# Altium schematic sheet DE-211024_1.SchDoc(DE-211024_1)
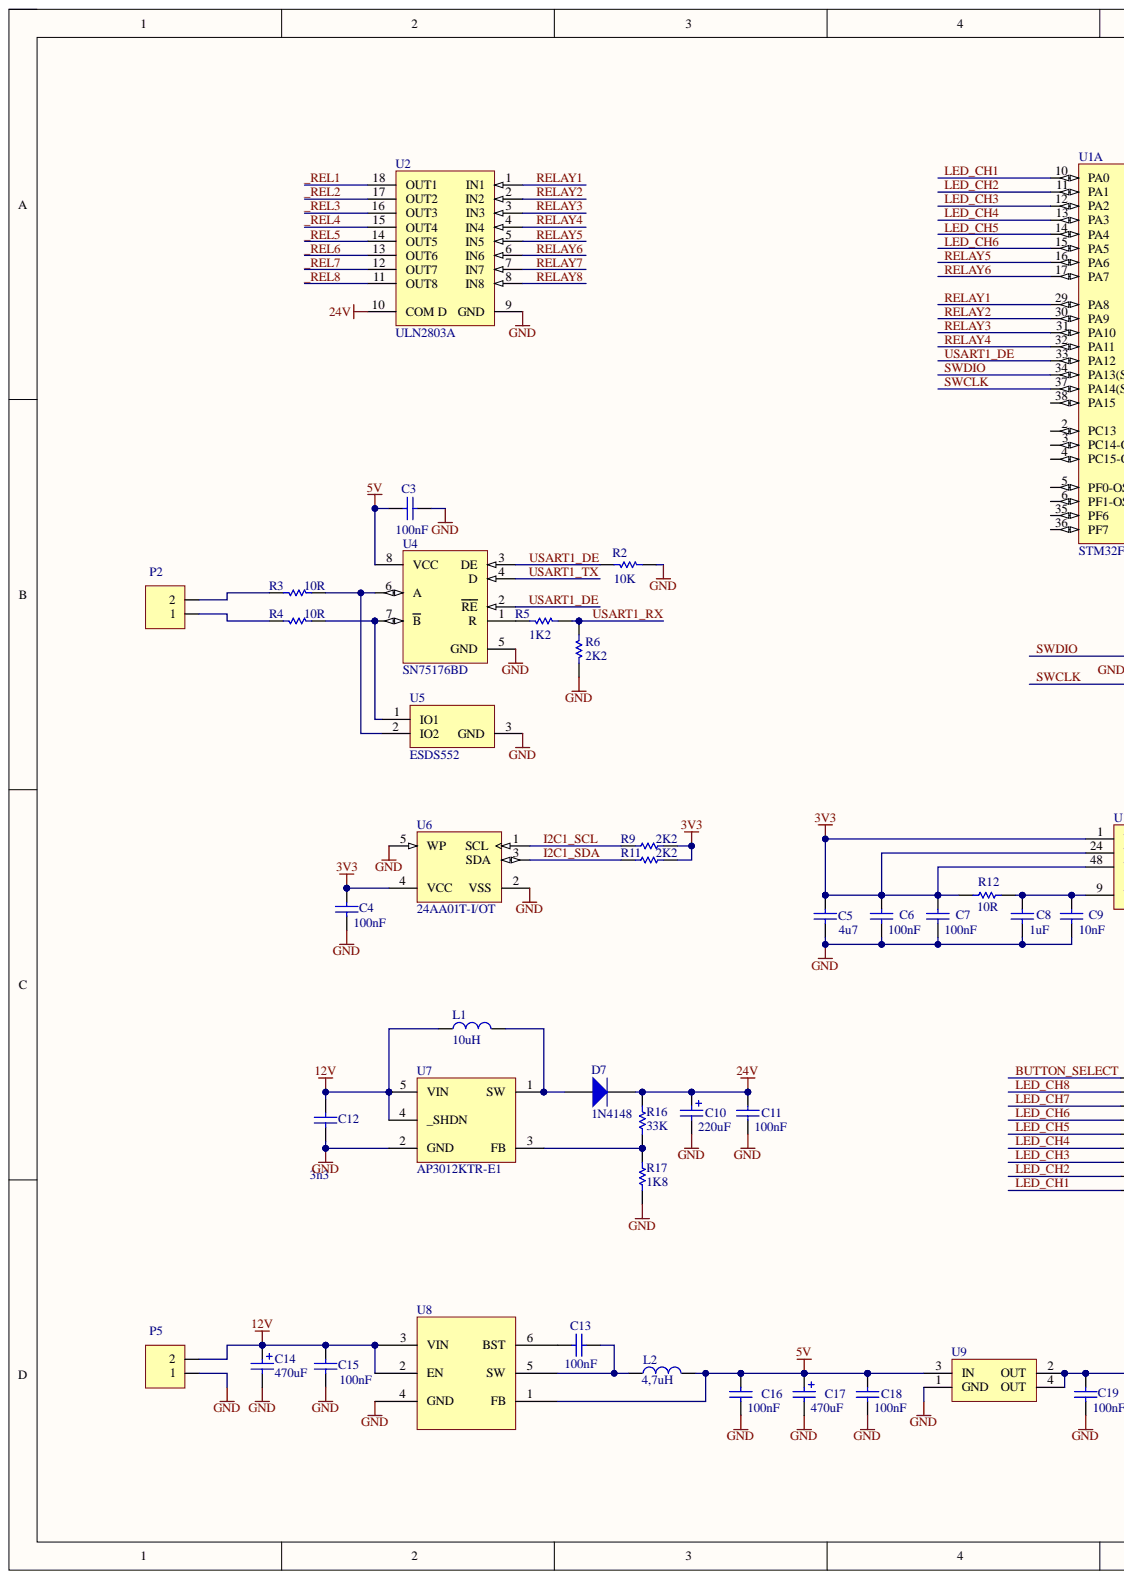
[diagram p0: DE-211024_1.SchDoc, left]
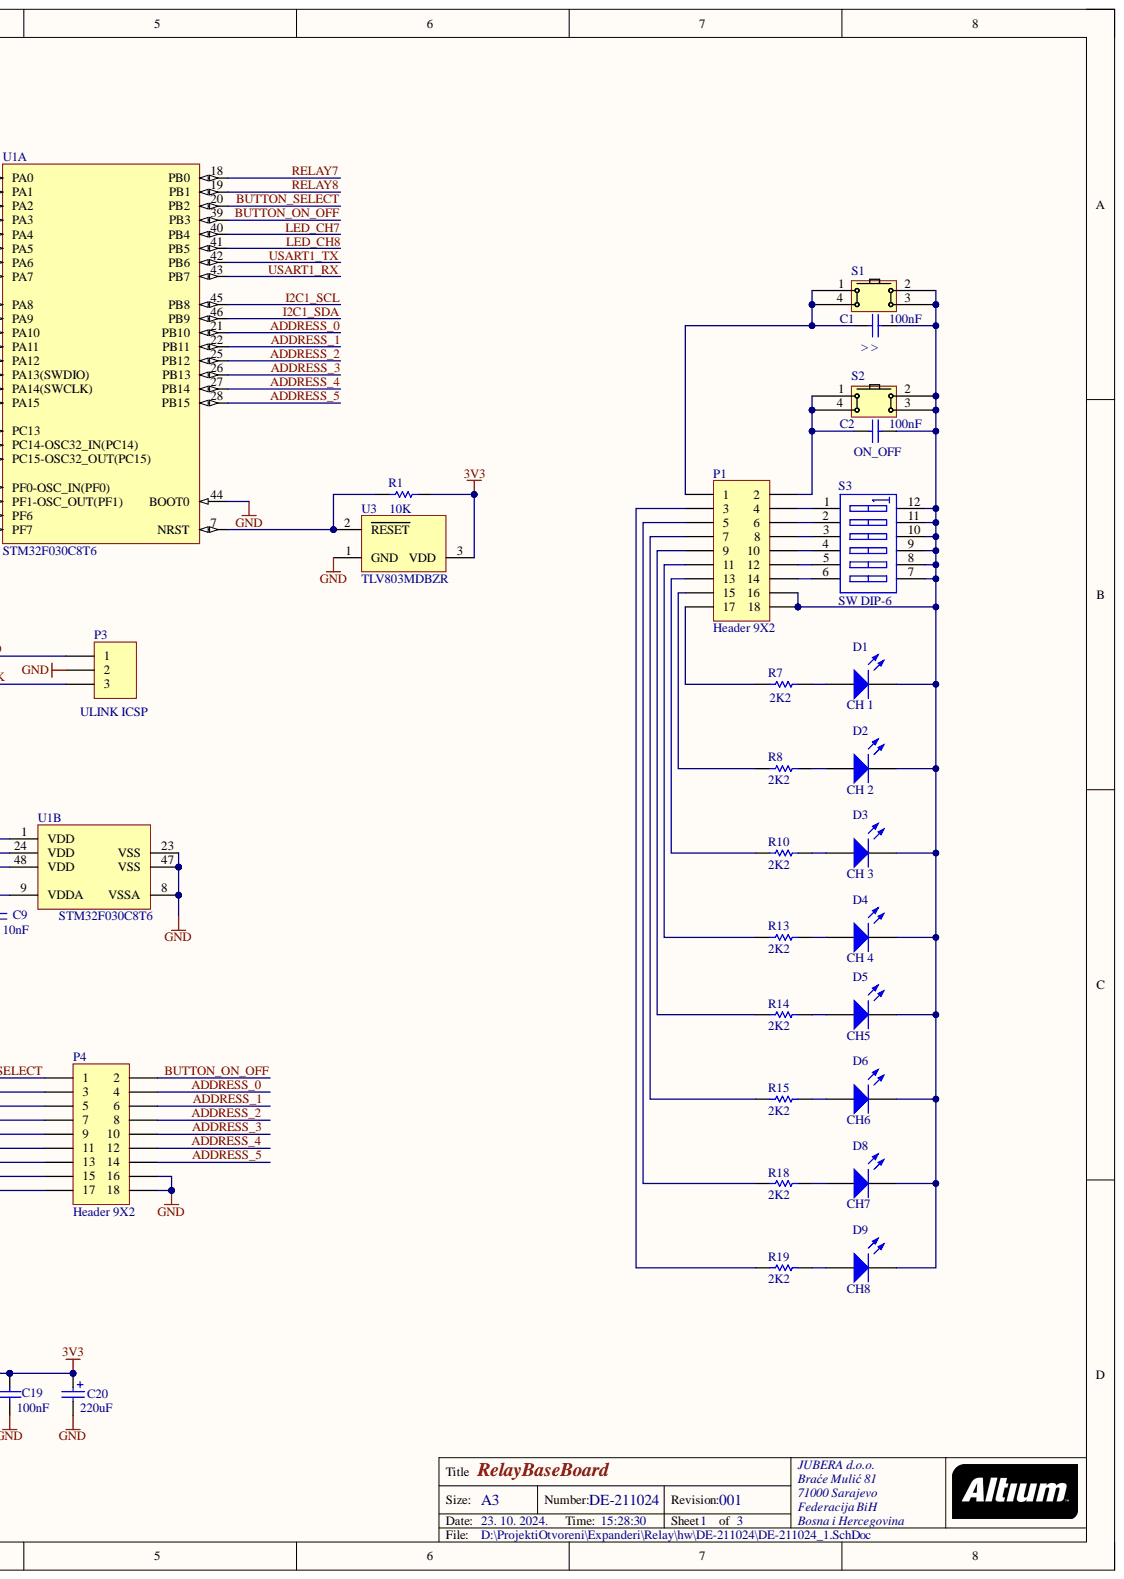
[diagram p1: DE-211024_1.SchDoc, right]

## Parts
C1  value 100nF  [0603]
C2  value 100nF  [0603]
C3  100nF  [0603]
C4  value 100nF  [0603]
C5  4u7  [0805]
C6  100nF  [0603]
C7  100nF  [0603]
C8  1uF  [0603]
C9  10nF  [0603]
C10  220uF  [SMD,D8xL10.2mm]
C11  value 100nF  [0603]
C12  value 3n3  [0603]  (hidden comment: C0603)
C13  value 100nF  [0603]
C14  470uF  [ROQANG VT1V471M1010]
C15  value 100nF  [0603]
C16  value 100nF  [0603]
C17  470uF  [SMD,D6.3xL7.7mm Aluminum Electrolytic Capacitors]
C18  value 100nF  [0603]
C19  value 100nF  [0603]
C20  value 220uF  [SMD,D6.3xL7.7mm Aluminum Electrolytic Capacitors]
D1  CH 1  [1.6X0.8X0.8]
D2  CH 2  [1.6X0.8X0.8]
D3  CH 3  [1.6X0.8X0.8]
D4  CH 4  [1.6X0.8X0.8]
D5  CH5  [1.6X0.8X0.8]
D6  CH6  [1.6X0.8X0.8]
D7  1N4148  [SOD323]
D8  CH7  [1.6X0.8X0.8]
D9  CH8  [1.6X0.8X0.8]
L1  10uH  [YJYCOIN CD32YP0302-100M]
L2  value 4,7uH  [XRNR4030-4.7uH/M]
P1  Header 9X2  [HDR2X9]
P2  (no value)  [TERMINAL BLOK 2]
P3  ULINK ICSP  [HDR1X3]
P4  Header 9X2  [HDR2X9]
P5  (no value)  [TERMINAL BLOK 2]
R1  10K  [ERA-0603]
R2  10K  [ERA-0603]
R3  10R  [ERA-0603]
R4  10R  [ERA-0603]
R5  1K2  [ERA-0603]
R6  2K2  [ERA-0603]
R7  2K2  [ERA-0603]
R8  2K2  [ERA-0603]
R9  2K2  [ERA-0603]
R10  2K2  [ERA-0603]
R11  2K2  [ERA-0603]
R12  10R  [ERA-0603]
R13  2K2  [ERA-0603]
R14  2K2  [ERA-0603]
R15  2K2  [ERA-0603]
R16  value 33K  [ERA-0603]  (hidden comment: 2K2)
R17  value 1K8  [ERA-0603]  (hidden comment: 2K2)
R18  2K2  [ERA-0603]
R19  2K2  [ERA-0603]
S1  > >  [TACTILE_SWITCH]
S2  ON_OFF  [TACTILE_SWITCH]
S3  SW DIP-6  [DIP-12/SW]
U1  STM32F030C8T6  [STM-LQFP48_N]  (parts A,B)
U2  ULN2803A  [SOIC-18_L11.6-W7.5-P1.27-LS10.3-BL]
U3  TLV803MDBZR  [DBZ3_N]
U4  SN75176BD  [D008_N]
U5  ESDS552  [DCK3_N]
U6  24AA01T-I/OT  [SOT-23-OT5_N]
U7  AP3012KTR-E1  [SOT-23-5]
U8  (no value)  [TSOT-26]
U9  (no value)  [ZZ311]

## Nets
3V3: C4.2 C5.1 C6.1 C7.1 C19.2 C20.1 R1.2 R9.2 R11.2 R12.2 U1.1(VDD) U1.24(VDD) U1.48(VDD) U3.3 U6.4(VCC) U9.2(OUT) U9.4(OUT)
5V: C3.1 C16.2 C17.1 C18.2 L2.1 U4.8(VCC) U8.1(FB) U9.3(IN)
12V: C12.2 C14.1 C15.2 L1.2 P5.2 U7.4(_SHDN) U7.5(VIN) U8.2(EN) U8.3(VIN)
24V: C10.1 C11.2 D7.1 R16.2 U2.10(COM_D)
GND: C3.2 C4.1 C5.2 C6.2 C7.2 C8.2 C9.2 C10.2 C11.1 C12.1 C14.2 C15.1 C16.1 C17.2 C18.1 C19.1 C20.2 P3.2 P4.16 P4.18 P5.1 R2.1 R6.2 R17.1 U1.8(VSSA) U1.23(VSS) U1.44(BOOT0) U1.47(VSS) U2.9(GND) U3.1 U4.5(GND) U5.3 U6.2(VSS) U6.5(WP) U7.2(GND) U8.4(GND) U9.1(GND)
_REL1: U2.18(OUT1)
_REL2: U2.17(OUT2)
_REL3: U2.16(OUT3)
_REL4: U2.15(OUT4)
_REL5: U2.14(OUT5)
_REL6: U2.13(OUT6)
_REL7: U2.12(OUT7)
_REL8: U2.11(OUT8)
ADDRESS_0: P4.4 U1.21(PB10)
ADDRESS_1: P4.6 U1.22(PB11)
ADDRESS_2: P4.8 U1.25(PB12)
ADDRESS_3: P4.10 U1.26(PB13)
ADDRESS_4: P4.12 U1.27(PB14)
ADDRESS_5: P4.14 U1.28(PB15)
BUTTON_ON_OFF: P4.2 U1.39(PB3)
BUTTON_SELECT: P4.1 U1.20(PB2)
I2C1_SCL: R9.1 U1.45(PB8) U6.1(SCL)
I2C1_SDA: R11.1 U1.46(PB9) U6.3(SDA)
LED_CH1: P4.17 U1.10(PA0)
LED_CH2: P4.15 U1.11(PA1)
LED_CH3: P4.13 U1.12(PA2)
LED_CH4: P4.11 U1.13(PA3)
LED_CH5: P4.9 U1.14(PA4)
LED_CH6: P4.7 U1.15(PA5)
LED_CH7: P4.5 U1.40(PB4)
LED_CH8: P4.3 U1.41(PB5)
RELAY1: U1.29(PA8) U2.1(IN1)
RELAY2: U1.30(PA9) U2.2(IN2)
RELAY3: U1.31(PA10) U2.3(IN3)
RELAY4: U1.32(PA11) U2.4(IN4)
RELAY5: U1.16(PA6) U2.5(IN5)
RELAY6: U1.17(PA7) U2.6(IN6)
RELAY7: U1.18(PB0) U2.7(IN7)
RELAY8: U1.19(PB1) U2.8(IN8)
SWCLK: P3.3 U1.37(PA14(SWCLK))
SWDIO: P3.1 U1.34(PA13(SWDIO))
USART1_DE: R2.2 U1.33(PA12) U4.2(RE) U4.3(DE)
USART1_RX: R5.1 R6.1 U1.43(PB7)
USART1_TX: U1.42(PB6) U4.4(D)
NetC1_1: C1.1 P1.1 S1.1 S1.4
NetC1_2: C1.2 C2.2 D1.2 D2.2 D3.2 D4.2 D5.2 D6.2 D8.2 D9.2 P1.16 P1.18 S1.2 S1.3 S2.2 S2.3 S3.7 S3.8 S3.9 S3.10 S3.11 S3.12
NetC2_1: C2.1 P1.2 S2.1 S2.4
NetC8_1: C8.1 C9.1 R12.1 U1.9(VDDA)
NetC13_1: C13.1 L2.2 U8.5(SW)
NetC13_2: C13.2 U8.6(BST)
NetD1_1: D1.1 R7.2
NetD2_1: D2.1 R8.2
NetD3_1: D3.1 R10.2
NetD4_1: D4.1 R13.2
NetD5_1: D5.1 R14.2
NetD6_1: D6.1 R15.2
NetD7_2: D7.2 L1.1 U7.1(SW)
NetD8_1: D8.1 R18.2
NetD9_1: D9.1 R19.2
NetP1_3: P1.3 R19.1
NetP1_4: P1.4 S3.1
NetP1_5: P1.5 R18.1
NetP1_6: P1.6 S3.2
NetP1_7: P1.7 R15.1
NetP1_8: P1.8 S3.3
NetP1_9: P1.9 R14.1
NetP1_10: P1.10 S3.4
NetP1_11: P1.11 R13.1
NetP1_12: P1.12 S3.5
NetP1_13: P1.13 R10.1
NetP1_14: P1.14 S3.6
NetP1_15: P1.15 R8.1
NetP1_17: P1.17 R7.1
NetP2_1: P2.1 R4.1
NetP2_2: P2.2 R3.1
NetR1_1: R1.1 U1.7(NRST) U3.2
NetR3_2: R3.2 U4.6(A) U5.2
NetR4_2: R4.2 U4.7(B) U5.1
NetR5_2: R5.2 U4.1(R)
NetR16_1: R16.1 R17.2 U7.3(FB)

Not connected: U1 2(PC13) 3(PC14-OSC32_IN(PC14)) 4(PC15-OSC32_OUT(PC15)) 5(PF0-OSC_IN(PF0)) 6(PF1-OSC_OUT(PF1)) 35(PF6) 36(PF7) 38(PA15)

## Library data of the parts
C1-C4 C6-C9 C11 C12 C15 C19 = C0603: Ceramic Chip Capacitor - Standard; Note: Footprint corresponds to dimensions for bulk cassette packaging. This case size has tightened tolerances.; Code_IPC: 1608 [0603]; Code_JEITA: 0603; Component Kind: Standard; ComponentLink1Description: Manufacturer Link; ComponentLink1URL: http://www.kemet.com/; ComponentLink2Description: Datasheet; ComponentLink2URL: http://www.kemet.com/kemet/web/homepage/kechome.nsf/vapubfilesname/F3102TA.pdf/$file/F3102TA.pdf; DatasheetDocument: Mar-2001; LatestRevisionDate: 19-Mar-2004; LatestRevisionNote: Silkscreen size reduced.; PackageDocument: Mar-2001; PackageReference: 0603; Published: 17-Jul-2002; Signal Integrity: C0603; Simulation: CAP; Publisher: Altium Limited
  C1 C2 C4 C11 C12 C15 C19: Library Name: DE-211024.SchLib
  C3: Library Name: DE-220817.SchLib; Value: 100nF
  C6 C7: Library Name: KEMET Chip Capacitor.IntLib; Value: 100nF
  C8: Library Name: KEMET Chip Capacitor.IntLib; Value: 1uF
  C9: Library Name: KEMET Chip Capacitor.IntLib; Value: 10nF
C5 = C0805: Ceramic Chip Capacitor - Standard; Note: Footprint corresponds to dimensions for bulk cassette packaging. This case size has tightened tolerances.; Code_IPC: 2012[0805]; Code_JEITA: 0805; Component Kind: Standard; ComponentLink1Description: Manufacturer Link; ComponentLink1URL: http://www.kemet.com/; ComponentLink2Description: Datasheet; ComponentLink2URL: http://www.kemet.com/kemet/web/homepage/kechome.nsf/vapubfilesname/F3102TA.pdf/$file/F3102TA.pdf; DatasheetDocument: Mar-2001; LatestRevisionDate: 19-Mar-2004; LatestRevisionNote: Silkscreen size reduced.; Library Name: KEMET Chip Capacitor.IntLib; PackageDocument: Mar-2001; PackageReference: 0805; Published: 17-Jul-2002; Signal Integrity: C0805; Simulation: CAP; Value: 4u7; Publisher: Altium Limited
C10 = ROQANG VT1V221M0810: 220uF 35V 195mA@120Hz ±20% SMD,D8xL10.2mm Aluminum Electrolytic Capacitors - SMD ROHS; DrillSize: Nominal, Rounded To The Nearest 0.1mm; Note: Refer to Manufacturer's Data Sheets for other lead formations; Component Kind: Standard; ComponentLink1Description: Manufacturer Link; ComponentLink1URL: http://www.vishay.com/; ComponentLink2Description: Datasheet; ComponentLink2URL: http://www.vishay.com/docs/25014/eka.pdf; DatasheetDocument: 20-Feb-2001; Library Name: DE-211024.SchLib; PackageReference: CAPPR2-5X11; Published: 19-Mar-2004; Value: 220uF; Publisher: Altium Limited
C13 C16 C18 = C0603: Ceramic Chip Capacitor - 25V; Note: Footprint corresponds to dimensions for bulk cassette packaging. This case size has tightened tolerances.; Code_IPC: 1608 [0603]; Code_JEITA: 0603; Component Kind: Standard; ComponentLink1Description: Manufacturer Link; ComponentLink1URL: http://www.kemet.com/; ComponentLink2Description: Datasheet; ComponentLink2URL: http://www.kemet.com/kemet/web/homepage/kechome.nsf/vapubfilesname/F3102TA.pdf/$file/F3102TA.pdf; DatasheetDocument: Mar-2001; LatestRevisionDate: 19-Mar-2004; LatestRevisionNote: Silkscreen size reduced.; Library Name: DE-211024.SchLib; PackageDocument: Mar-2001; PackageReference: 0603; Published: 17-Jul-2002; Signal Integrity: C0603; Simulation: CAP; Publisher: Altium Limited
C14 = ROQANG VT1C221M0607: 220uF 16V 110mA@120Hz ±20% SMD,D6.3xL7.7mm Aluminum Electrolytic Capacitors - SMD ROHS; DrillSize: Nominal, Rounded To The Nearest 0.1mm; Note: Refer to Manufacturer's Data Sheets for other lead formations; Value: 10uF; Component Kind: Standard; ComponentLink1Description: Manufacturer Link; ComponentLink1URL: http://www.vishay.com/; ComponentLink2Description: Datasheet; ComponentLink2URL: http://www.vishay.com/docs/25014/eka.pdf; DatasheetDocument: 20-Feb-2001; Library Name: DE-180824.SchLib; PackageReference: CAPPR2-5X11; Published: 19-Mar-2004; Publisher: Altium Limited
C17 C20 = ROQANG VT1C221M0607: 220uF 16V 110mA@120Hz ±20% SMD,D6.3xL7.7mm Aluminum Electrolytic Capacitors - SMD ROHS; DrillSize: Nominal, Rounded To The Nearest 0.1mm; Note: Refer to Manufacturer's Data Sheets for other lead formations; Component Kind: Standard; ComponentLink1Description: Manufacturer Link; ComponentLink1URL: http://www.vishay.com/; ComponentLink2Description: Datasheet; ComponentLink2URL: http://www.vishay.com/docs/25014/eka.pdf; DatasheetDocument: 20-Feb-2001; PackageReference: CAPPR2-5X11; Published: 19-Mar-2004; Publisher: Altium Limited
  C17: Library Name: DE-180824.SchLib; Value: 470uF
  C20: Library Name: DE-211024.SchLib
D1-D6 D8 D9 = HSMZ-C190: High Performance Chip LED, Red; LuminousIntensity: 40mcd (Minimum).; PCB_Layout: Complies with manufacturer's recommendation.; Component Kind: Standard; ComponentLink1Description: Manufacturer Link; ComponentLink1URL: http://www.agilent.com/; ComponentLink2Description: Datasheet; ComponentLink2URL: http://cp.literature.agilent.com/litweb/pdf/5988-5891EN.pdf; DatasheetDocument: 11-Mar-2002; LED_Colour: Red; Library Name: DE-180824.SchLib; PackageDocument: 11-Mar-2002; PackageReference: 1.6X0.8X0.8; Published: 11-Dec-2002; Signal Integrity: LED; Simulation: Agl_HSMZC110; Publisher: Altium Limited
D7 = BAS321: General-purpose Diode; Component Kind: Standard; ComponentLink1Description: Manufacturer Link; ComponentLink1URL: http://www.semiconductors.philips.com/; ComponentLink2Description: Datasheet; ComponentLink2URL: http://www.semiconductors.philips.com/acrobat/datasheets/BAS321_2.pdf; DatasheetVersion: 9-Feb-1999; LatestRevisionDate: 19-Mar-2004; LatestRevisionNote: Silkscreen size reduced.; Library Name: DE-211024.SchLib; PackageDescription: SOD-323/SC-76; 2 Leads; Body 1.7 x 1.3 mm, (LxW); PackageReference: SOD323; PackageVersion: May-1999; Published: 17-Sep-2003; Signal Integrity: BAS321; Simulation: BAS321; Publisher: Altium Limited
L1 = Inductor: Component Kind: Standard; Library Name: DE-211024.SchLib
L2 = Inductor: 2A 4.7uH Magnetic Adhesive Inductor ±20% 2.9A SMD-2P,4x4mm Power Inductors ROHS; Component Kind: Standard; Library Name: DE-211024.SchLib
P1 P4 = Header 9X2: Header, 9-Pin, Dual row; Component Kind: Standard; LatestRevisionDate: 17-Jul-2002; LatestRevisionNote: Re-released for DXP Platform.; Library Name: Miscellaneous Connectors.IntLib; Signal Integrity: Connector; Publisher: Altium Limited
P2 P5 = TERMINAL BLOK 2: Terminal blok konektor 3,5 mm; Component Kind: Standard; Library Name: DE-211024.SchLib
P3 = Header 3: Header, 3-Pin; Component Kind: Standard; LatestRevisionDate: 17-Jul-2002; LatestRevisionNote: Re-released for DXP Platform.; Library Name: DE-180824.SchLib; Signal Integrity: Connector; Publisher: Altium Limited
R1 R7-R11 R13-R19 = ERA3Y: Rectangular Thick Film Chip Resistor, 10 Ohm to 330k Ohm Range, 0.1% and 0.5% Tolerance, 0603 Size, 0.063 W; Note: If flow soldering, adjust pad width of PCB Footprint to 0.7 - 0.8 times the width of the resistor.; Code_IPC: 1608 [0603]; Component Kind: Standard; ComponentLink1Description: Manufacturer Link; ComponentLink1URL: http://www.panasonic.com/industrial/components/resistive_products.htm; ComponentLink2Description: Datasheet; ComponentLink2URL: http://www.panasonic.com/industrial/components/pdf/AOA0000CE8.pdf; LatestRevisionDate: 19-Mar-2004; LatestRevisionNote: Silkscreen size reduced.; PackageDocument: Mar-2003; PackageReference: ERA-0603; Published: 17-Dec-2003; Signal Integrity: Res; Simulation: RESISTOR; Publisher: Altium Limited
  R1: Library Name: DE-120824.SchLib; Value: 10K
  R7 R8 R10 R13-R15 R18 R19: Library Name: DE-180824.SchLib
  R9 R11: Library Name: DE-120824.SchLib; Value: 2K2
  R16 R17: Library Name: DE-120824.SchLib
R2-R6 = ERAV: Metal Film Thermosensitive Chip Resistor, 0.56 Ohm to 10k Ohm Range, 5% Tolerance, 0603 Size, 0.063 W; Note: If flow soldering, adjust pad width of PCB Footprint to 0.7 - 0.8 times the width of the resistor.; Code_IPC: 1608 [0603]; Component Kind: Standard; ComponentLink1Description: Manufacturer Link; ComponentLink1URL: http://www.panasonic.com/industrial/components/resistive_products.htm; ComponentLink2Description: Datasheet; ComponentLink2URL: http://www.panasonic.com/industrial/components/pdf/AOF0000CE1.pdf; LatestRevisionDate: 19-Mar-2004; LatestRevisionNote: Silkscreen size reduced.; Library Name: DE-220817.SchLib; PackageDocument: Mar-2003; PackageReference: ERA-0603; Published: 17-Dec-2003; Signal Integrity: Res; Simulation: RESISTOR; Publisher: Altium Limited
  R2: Value: 10K
  R3 R4: Value: 10R
  R5: Value: 1K2
  R6: Value: 2K2
R12 = ERA6Y: Rectangular Thick Film Chip Resistor, 10 Ohm to 1M Ohm Range, 0.1% and 0.5% Tolerance, 0805 Size, 0.1 W; Note: If flow soldering, adjust pad width of PCB Footprint to 0.7 - 0.8 times the width of the resistor.; Code_IPC: 2012 [0805]; Component Kind: Standard; ComponentLink1Description: Manufacturer Link; ComponentLink1URL: http://www.panasonic.com/industrial/components/resistive_products.htm; ComponentLink2Description: Datasheet; ComponentLink2URL: http://www.panasonic.com/industrial/components/pdf/AOA0000CE8.pdf; LatestRevisionDate: 19-Mar-2004; LatestRevisionNote: Silkscreen size reduced.; Library Name: DE-280817.SchLib; PackageDocument: Mar-2003; PackageReference: ERA-0805; Published: 17-Dec-2003; Signal Integrity: Res; Simulation: RESISTOR; Value: 10R; Publisher: Altium Limited
S1 S2 = TACKTILE_SWITCH: Component Kind: Standard; Library Name: DE-180824.SchLib
S3 = SW DIP-6: DIP Switch, 6 Position, SPST; Component Kind: Standard; LatestRevisionDate: 17-Jul-2002; LatestRevisionNote: Re-released for DXP Platform.; Library Name: Miscellaneous Devices.IntLib; PackageDescription: DIP Switch; 12 Leads; PackageReference: DIP-12/SW; Published: 24-Mar-1999; Simulation: dpsw6; Publisher: Altium Limited
U1 = STM32F030C8T6: ARM Cortex-M0 32-bit MCU, 64 KB Flash, 8 KB Internal RAM, 39 I/Os, 48-pin LQFP, -40 to 85 degC, Tray; FLASH Size (Prog) (kB): 64; I/Os (High Current): 39; Internal RAM Size (kB): 8; Operating Frequency(F) (Processor speed) (MHz): 48; Supply Voltage(Vcc) min (V): 2.4; Component Kind: Standard; ComponentLink1Description: Manufacturer URL; ComponentLink1URL: http://www.st.com/web/en/home.html; ComponentLink2Description: Datasheet; ComponentLink2URL: http://www.st.com/st-web-ui/static/active/en/resource/technical/document/datasheet/DM00088500.pdf; ComponentLink3Description: Package Specification; ComponentLink3URL: http://www.st.com/st-web-ui/static/active/en/resource/technical/document/datasheet/DM00058181.pdf; Core: ARM Cortex-M0; DatasheetVersion: Rev. 1; Library Name: STMicroelectronics STM32 F0.IntLib; Mounting Technology: Surface Mount; PackageDescription: 48-Pin Low Profile Quad Flat Package, 7 x 7 mm Body, 0.5 mm Pitch; PackageReference: LQFP48; PackageVersion: Rev. 6, 06/2013; Packing: Tray; PartNumber: STM32F030C8T6; Supplier 1: Digi-Key; Supplier 2: Newark; Supplier 3: Mouser; Supplier Part Number 1: 497-14043-ND; Supplier Part Number 2: 06X3647; Supplier Part Number 3: 511-STM32F030C8T6
U2 = ULN2803A: High-Voltage, High-Current Darlington Array; Component Kind: Standard; ComponentLink1Description: Manufacturer Link; ComponentLink1URL: http://www.allegromicro.com/; DatasheetVersion: 1999; LatestRevisionDate: 06-Jun-2005; LatestRevisionNote: Stylized 3D Model Added.; Library Name: DE-211024.SchLib; PackageDescription: DIP; 18 Leads; Row Spacing 7.62 mm; Pitch 2.54 mm; PackageReference: A18; PackageVersion: 1999; Published: 13-Oct-2000; Publisher: Altium Limited
U3 = TLV803MDBZR: Voltage Supervisor with Active-Low, Open Drain Reset, 1 Supply Monitored, -40 to 125 degC, 3-pin SOT-23 (DBZ), Green (RoHS & no Sb/Br); Manufacturer: Texas Instruments; # of supplies monitored: 1; Iq(Typ)(uA): 9; Output Driver Type / Reset Output: Active-low, Open-drain; Rating: Catalog; Reset Threshold Accuracy(%): 2; SubFamily: Supervisor and Reset IC; Threshold Voltage 1 (typ)(Typ)(V): 4.38; Time Delay(ms): 200; VCC(Max)(V): 6; VCC(Min)(V): 1.1; Code_JEDEC: TO-236-AB; Component Kind: Standard; ComponentLink1Description: Datasheet; ComponentLink1URL: http://www.ti.com/general/docs/lit/getliterature.tsp?genericPartNumber=TLV803M&fileType=pdf; DatasheetVersion: SBVS157B; Library Name: TI Power Management Supervisor and Reset IC.IntLib; Mounting Technology: Surface Mount; PackageDescription: 3-Pin Plastic Small-Outline Package, 0.95 mm Pitch, 2.4 mm Lead Span; PackageReference: DBZ3; PackageVersion: revB, Apr-2005; PartNumber: TLV803MDBZR; Supplier 1: Digi-Key; Supplier 2: Digi-Key; Supplier 3: Digi-Key; Supplier 4: Newark; Supplier 5: Mouser; Supplier Part Number 1: 296-29194-2-ND; Supplier Part Number 2: 296-29194-1-ND; Supplier Part Number 3: 296-29194-6-ND; Supplier Part Number 4: 58T0286; Supplier Part Number 5: 595-TLV803MDBZR
U4 = SN75176BD: Differential Bus Transceiver; Note: Receiver Hysteresis of 50mV Typical; Code_IPC: SOIC127P600-8; Component Kind: Standard; ComponentLink1Description: Manufacturer Link; ComponentLink1URL: http://www.ti.com/; ComponentLink2Description: Datasheet; ComponentLink2URL: http://www-s.ti.com/sc/ds/sn75176b.pdf; DatasheetVersion: Sep-1989; LatestRevisionDate: 13-Apr-2006; LatestRevisionNote: IPC-7351 Footprint Added.; Library Name: DE-010824.SchLib; PackageDescription: 8-Pin Small Outline Integrated Circuit 1.27 mm Pitch; PackageReference: D008; PackageVersion: Jan-1998; PCB3D: D008; Published: 15-May-2002; Publisher: Altium Limited
U5 = TPD2E007DCKR: ESD Protection Array for AC Signal Data Interface, 2 Channels, -40 to +85 degC, 3-pin SC70 (DCK), Green (RoHS & no Sb/Br); Manufacturer: Texas Instruments; Breakdown Voltage(Min)(V): +/-14; IEC 61000-4-2 Air-Gap(+/- kV): 15; IEC 61000-4-2 Contact(+/- kV): 8; IO Leakage Current(nA): 50; SubFamily: ESD Solution; Component Kind: Standard; ComponentLink1Description: Datasheet; ComponentLink1URL: http://www.ti.com/general/docs/lit/getliterature.tsp?genericPartNumber=TPD2E007&fileType=pdf; ComponentLink2Description: URL Link1; ComponentLink2URL: SamplesURL:http://www.ti.com/product/tpd2e007#samples; DatasheetVersion: SLVS796E; Eco-Plan: Green (RoHS & no Sb/Br); IO Capacitance(Typ)(pF): 15; Library Name: TI Interface ESD EMI Protection.IntLib; Mounting Technology: Surface Mount; PackageDescription: 3-Pin Plastic Small-Outline Package, 0.65 mm Pitch, 2.1 mm Lead Span; PackageReference: DCK3; PackageVersion: revG, Jan-2007; PartNumber: TPD2E007DCKR; Supplier 1: Digi-Key; Supplier 2: Digi-Key; Supplier 3: Digi-Key; Supplier 4: Farnell; Supplier 5: Newark; Supplier 6: Newark; Supplier 7: Mouser; Supplier Part Number 1: 296-25281-2-ND; Supplier Part Number 2: 296-25281-1-ND; Supplier Part Number 3: 296-25281-6-ND; Supplier Part Number 4: 2120465; Supplier Part Number 5: 94T5089; Supplier Part Number 6: 55R7554; Supplier Part Number 7: 595-TPD2E007DCKR
U6 = 24AA01T-I/OT: 1Kbit, 400kHz, 1.8V, I2C Serial EEPROM, 5-Pin SOT-23, Industrial Temperature, Tape and Reel; Code_IPC: SOT23-5; Component Kind: Standard; ComponentLink1Description: Datasheet; ComponentLink1URL: http://ww1.microchip.com/downloads/en/DeviceDoc/21711J.pdf; ComponentLink2Description: Packaging Specification; ComponentLink2URL: http://www.microchip.com/stellent/groups/techpub_sg/documents/packagingspec/en012702.pdf; ComponentLink3Description: Microchip.com Home; ComponentLink3URL: http://www.microchip.com/; DatasheetVersion: revJ, Jan-2009; Library Name: Microchip Memory I2C Serial EEPROM.IntLib; PackageDescription: 5-Lead Plastic Small Outline Transistor (OT) [SOT-23]; PackageReference: SOT-23-OT5; PackageVersion: revBB, Aug-2009; Published: 29-Jan-2009; Supplier 1: Digi-Key; Supplier 2: Digi-Key; Supplier 3: Digi-Key; Supplier 4: Farnell; Supplier Part Number 1: 24AA01T-I/OTTR-ND; Supplier Part Number 2: 24AA01T-I/OTCT-ND; Supplier Part Number 3: 24AA01T-I/OTDKR-ND; Supplier Part Number 4: 1331269; Publisher: Altium Limited
U7 = AP3012: Diodes Incorporated AP3012KTR-E1 Boost type Adjustable 1.25V~29V 500mA 2.6V~16V SOT-23-5 DC-DC Converters ROHS; Component Kind: Standard; Library Name: DE-211024.SchLib
U8 = AP63200: 2A synchronous  buck converter; Component Kind: Standard; Library Name: DE-211024.SchLib
U9 = REG1117-3.3: 800mA and 1A Low Dropout Positive Regulator 2.85V, 3.3V, 5V, and Adjustable; Component Kind: Standard; ComponentLink1Description: Manufacturer Link; ComponentLink1URL: http://www.ti.com/; ComponentLink2Description: Datasheet; ComponentLink2URL: http://www-s.ti.com/sc/ds/REG1117.pdf; DatasheetVersion: Sep-1997; LatestRevisionDate: 17-Jul-2002; LatestRevisionNote: Re-released for DXP Platform.; Library Name: DE-211024.SchLib; PackageDescription: SOT-223/SC-73; 4 Leads; Body 6.7 x 7.3 mm, inc. leads (LxW max); PackageReference: ZZ311; PackageVersion: revC; Published: 8-Jun-2000; Publisher: Altium Limited
